# Altium schematic sheet H-9220 Backplane Board - DUT Bd3-4 (REV07).SchDoc
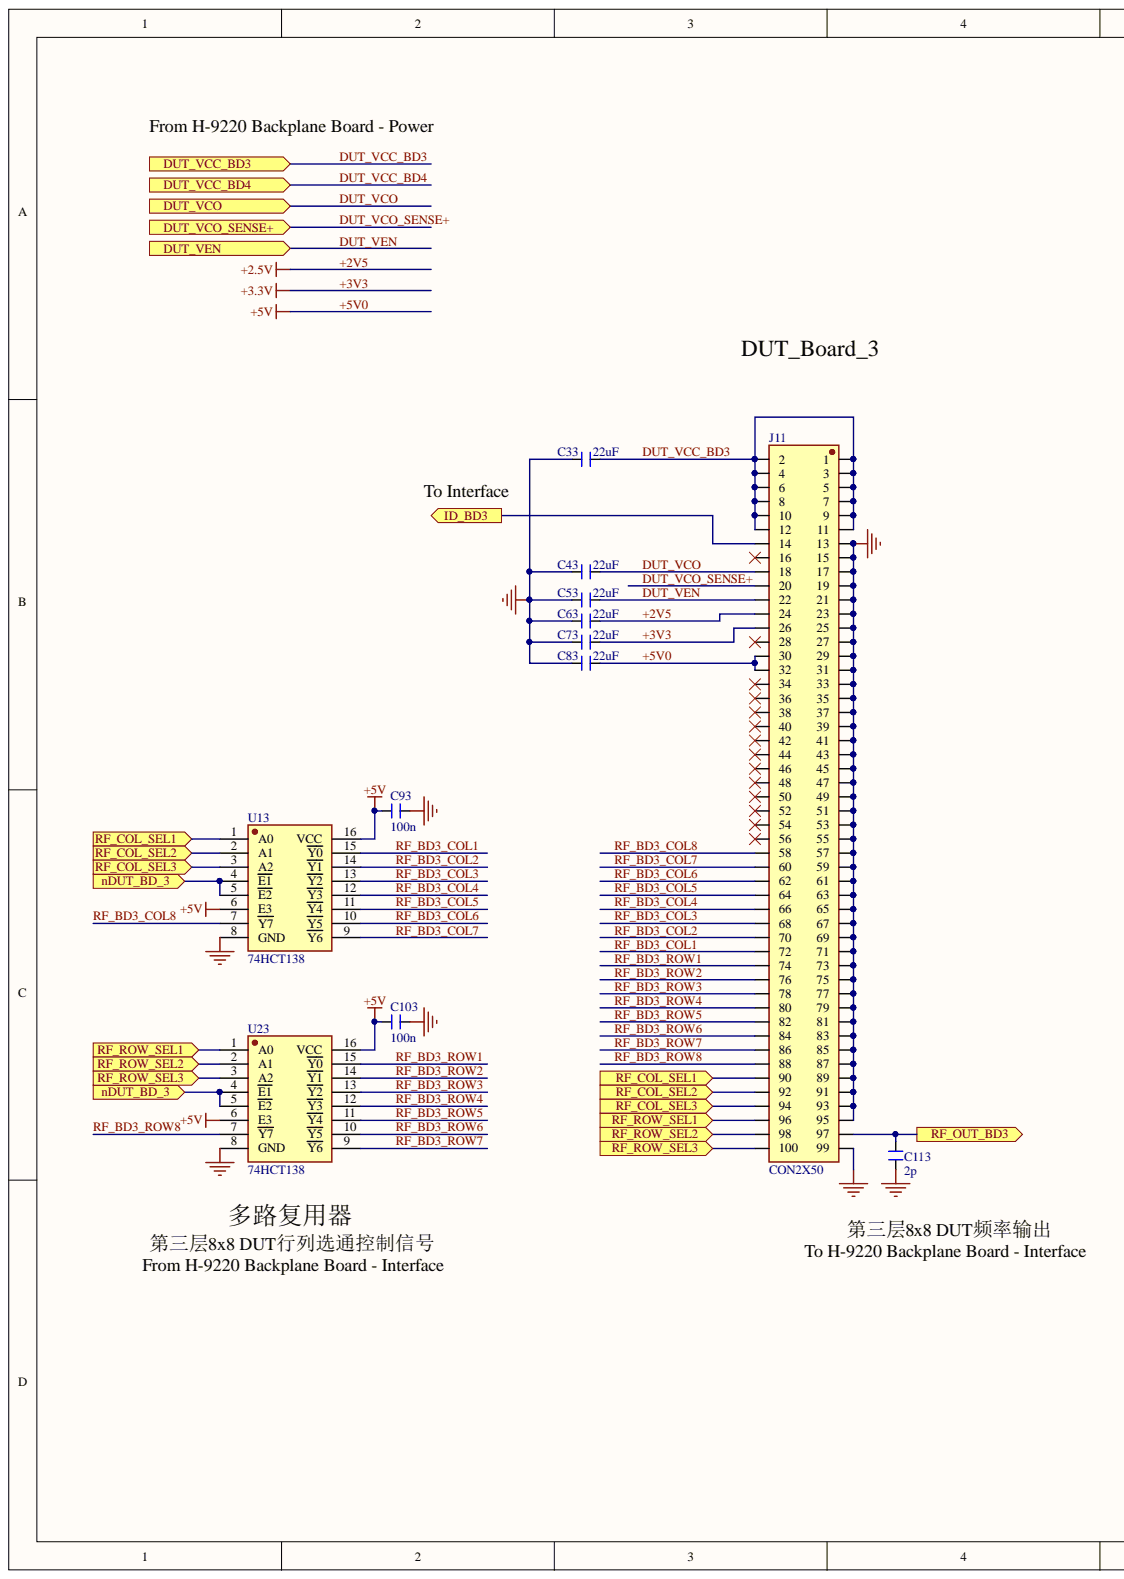
[diagram p0: H-9220 Backplane Board - DUT Bd3-4 (REV07).SchDoc, left]
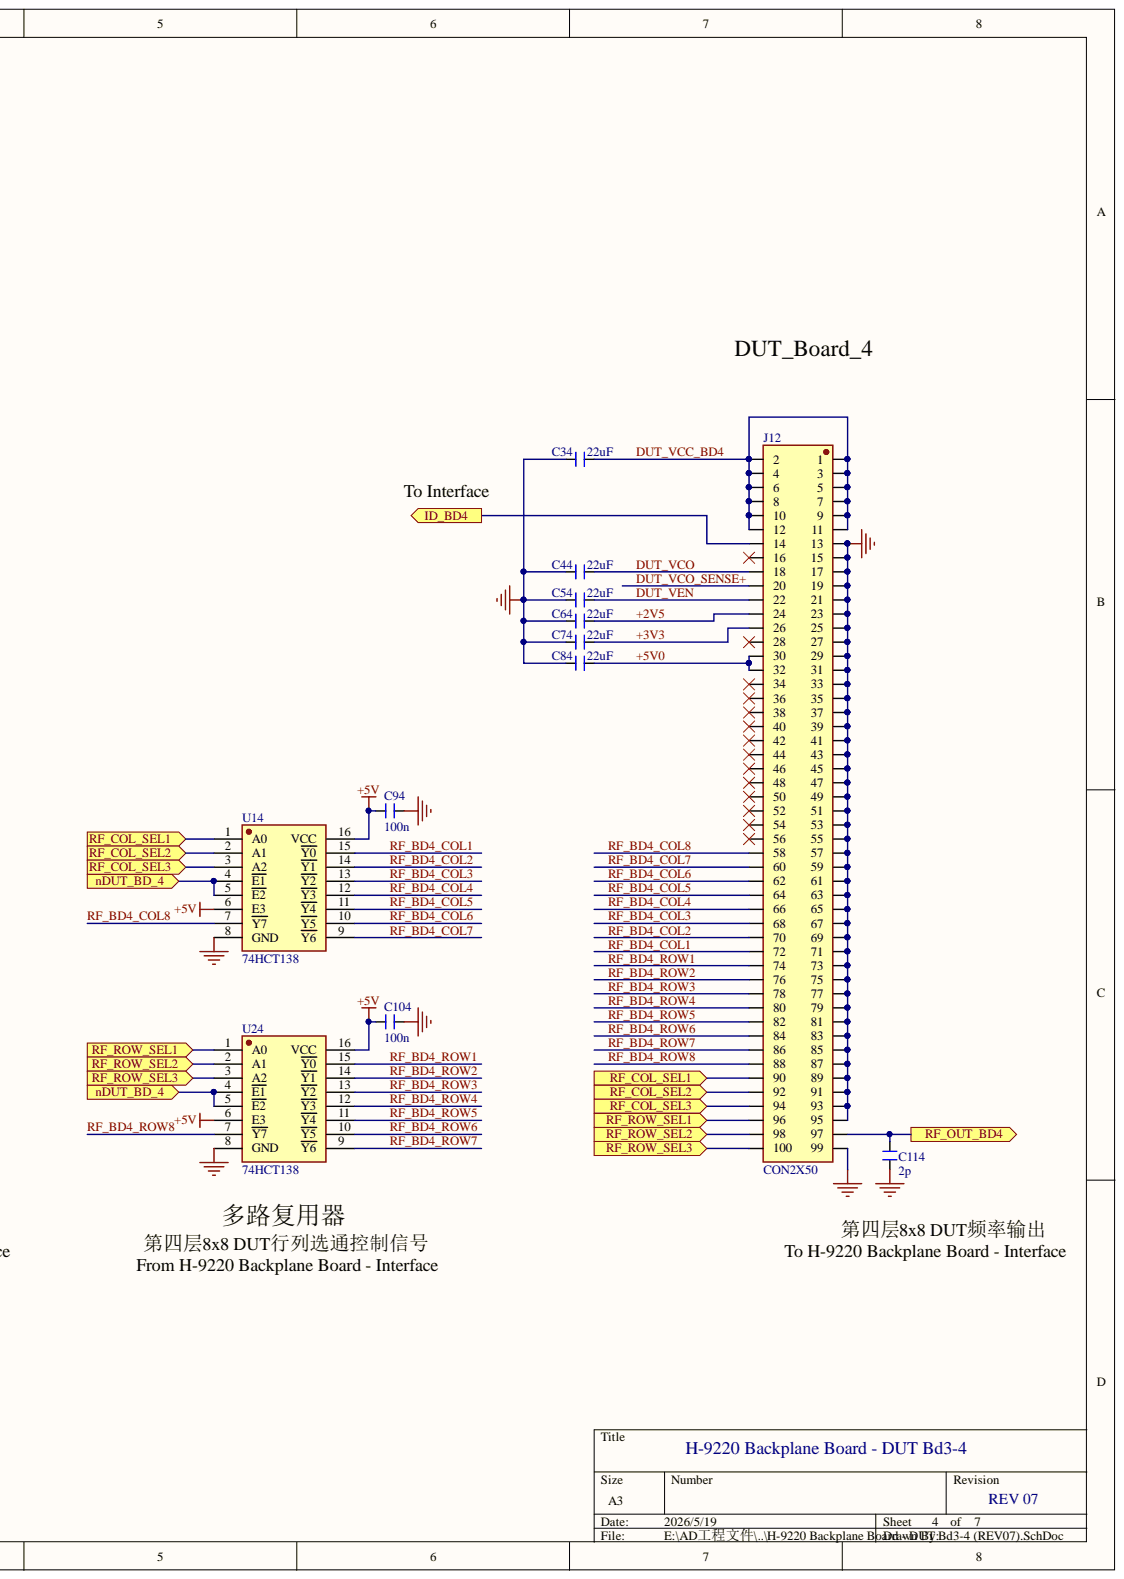
[diagram p1: H-9220 Backplane Board - DUT Bd3-4 (REV07).SchDoc, right]

## Parts
C33  22uF  [C-1206]
C34  22uF  [C-1206]
C43  22uF  [C-1206]
C44  22uF  [C-1206]
C53  22uF  [C-1206]
C54  22uF  [C-1206]
C63  22uF  [C-1206]
C64  22uF  [C-1206]
C73  22uF  [C-1206]
C74  22uF  [C-1206]
C83  22uF  [C-1206]
C84  22uF  [C-1206]
C93  100n  [C-0603]
C94  100n  [C-0603]
C103  100n  [C-0603]
C104  100n  [C-0603]
C113  2p  [C-0603]
C114  2p  [C-0603]
J11  CON2X50  [SFML-150-01-S-D-LC]
J12  CON2X50  [SFML-150-01-S-D-LC]
U13  74HCT138  [SOIC-16 (BODY=3.9MM)]
U14  74HCT138  [SOIC-16 (BODY=3.9MM)]
U23  74HCT138  [SOIC-16 (BODY=3.9MM)]
U24  74HCT138  [SOIC-16 (BODY=3.9MM)]

## Ports
DUT_VCC_BD3, DUT_VCC_BD4, DUT_VCO, DUT_VCO_SENSE+, DUT_VEN, ID_BD3, ID_BD4, nDUT_BD_3, nDUT_BD_4, RF_COL_SEL1, RF_COL_SEL2, RF_COL_SEL3, RF_OUT_BD3, RF_OUT_BD4, RF_ROW_SEL1, RF_ROW_SEL2, RF_ROW_SEL3

## Nets
GND: C33.2 C34.2 C43.2 C44.2 C53.2 C54.2 C63.2 C64.2 C73.2 C74.2 C83.2 C84.2 C93.2 C94.2 C103.2 C104.2 C113.2 C114.2 J11.13 J11.15 J11.17 J11.19 J11.21 J11.23 J11.25 J11.27 J11.29 J11.31 J11.33 J11.35 J11.37 J11.39 J11.41 J11.43 J11.45 J11.47 J11.49 J11.51 J11.53 J11.55 J11.57 J11.59 J11.61 J11.63 J11.65 J11.67 J11.69 J11.71 J11.73 J11.75 J11.77 J11.79 J11.81 J11.83 J11.85 J11.87 J11.89 J11.91 J11.93 J11.95 J11.99 J12.13 J12.15 J12.17 J12.19 J12.21 J12.23 J12.25 J12.27 J12.29 J12.31 J12.33 J12.35 J12.37 J12.39 J12.41 J12.43 J12.45 J12.47 J12.49 J12.51 J12.53 J12.55 J12.57 J12.59 J12.61 J12.63 J12.65 J12.67 J12.69 J12.71 J12.73 J12.75 J12.77 J12.79 J12.81 J12.83 J12.85 J12.87 J12.89 J12.91 J12.93 J12.95 J12.99 U13.8(GND) U14.8(GND) U23.8(GND) U24.8(GND)
+2V5: C63.1 C64.1 J11.24 J12.24
+3V3: C73.1 C74.1 J11.26 J12.26
+5V0: C83.1 C84.1 C93.1 C94.1 C103.1 C104.1 J11.30 J11.32 J12.30 J12.32 U13.6(E3) U13.16(VCC) U14.6(E3) U14.16(VCC) U23.6(E3) U23.16(VCC) U24.6(E3) U24.16(VCC)
DUT_VCC_BD3: C33.1 J11.1 J11.2 J11.3 J11.4 J11.5 J11.6 J11.7 J11.8 J11.9 J11.10 J11.11 J11.12 ; port DUT_VCC_BD3
DUT_VCC_BD4: C34.1 J12.1 J12.2 J12.3 J12.4 J12.5 J12.6 J12.7 J12.8 J12.9 J12.10 J12.11 J12.12 ; port DUT_VCC_BD4
DUT_VCO: C43.1 C44.1 J11.18 J12.18 ; port DUT_VCO
DUT_VCO_SENSE+: J11.20 J12.20 ; port DUT_VCO_SENSE+
DUT_VEN: C53.1 C54.1 J11.22 J12.22 ; port DUT_VEN
ID_BD3: J11.14 ; port ID_BD3
ID_BD4: J12.14 ; port ID_BD4
nDUT_BD_3: U13.4(E1) U13.5(E2) U23.4(E1) U23.5(E2) ; port nDUT_BD_3
nDUT_BD_4: U14.4(E1) U14.5(E2) U24.4(E1) U24.5(E2) ; port nDUT_BD_4
RF_BD3_COL1: J11.72 U13.15(Y0)
RF_BD3_COL2: J11.70 U13.14(Y1)
RF_BD3_COL3: J11.68 U13.13(Y2)
RF_BD3_COL4: J11.66 U13.12(Y3)
RF_BD3_COL5: J11.64 U13.11(Y4)
RF_BD3_COL6: J11.62 U13.10(Y5)
RF_BD3_COL7: J11.60 U13.9(Y6)
RF_BD3_COL8: J11.58 U13.7(Y7)
RF_BD3_ROW1: J11.74 U23.15(Y0)
RF_BD3_ROW2: J11.76 U23.14(Y1)
RF_BD3_ROW3: J11.78 U23.13(Y2)
RF_BD3_ROW4: J11.80 U23.12(Y3)
RF_BD3_ROW5: J11.82 U23.11(Y4)
RF_BD3_ROW6: J11.84 U23.10(Y5)
RF_BD3_ROW7: J11.86 U23.9(Y6)
RF_BD3_ROW8: J11.88 U23.7(Y7)
RF_BD4_COL1: J12.72 U14.15(Y0)
RF_BD4_COL2: J12.70 U14.14(Y1)
RF_BD4_COL3: J12.68 U14.13(Y2)
RF_BD4_COL4: J12.66 U14.12(Y3)
RF_BD4_COL5: J12.64 U14.11(Y4)
RF_BD4_COL6: J12.62 U14.10(Y5)
RF_BD4_COL7: J12.60 U14.9(Y6)
RF_BD4_COL8: J12.58 U14.7(Y7)
RF_BD4_ROW1: J12.74 U24.15(Y0)
RF_BD4_ROW2: J12.76 U24.14(Y1)
RF_BD4_ROW3: J12.78 U24.13(Y2)
RF_BD4_ROW4: J12.80 U24.12(Y3)
RF_BD4_ROW5: J12.82 U24.11(Y4)
RF_BD4_ROW6: J12.84 U24.10(Y5)
RF_BD4_ROW7: J12.86 U24.9(Y6)
RF_BD4_ROW8: J12.88 U24.7(Y7)
RF_COL_SEL1: J11.90 J12.90 U13.1(A0) U14.1(A0) ; port RF_COL_SEL1
RF_COL_SEL2: J11.92 J12.92 U13.2(A1) U14.2(A1) ; port RF_COL_SEL2
RF_COL_SEL3: J11.94 J12.94 U13.3(A2) U14.3(A2) ; port RF_COL_SEL3
RF_OUT_BD3: C113.1 J11.97 ; port RF_OUT_BD3
RF_OUT_BD4: C114.1 J12.97 ; port RF_OUT_BD4
RF_ROW_SEL1: J11.96 J12.96 U23.1(A0) U24.1(A0) ; port RF_ROW_SEL1
RF_ROW_SEL2: J11.98 J12.98 U23.2(A1) U24.2(A1) ; port RF_ROW_SEL2
RF_ROW_SEL3: J11.100 J12.100 U23.3(A2) U24.3(A2) ; port RF_ROW_SEL3

Not connected: J11 16 28 34 36 38 40 42 44 46 48 50 52 54 56; J12 16 28 34 36 38 40 42 44 46 48 50 52 54 56

## Library data of the parts
C33 C34 C43 C44 C53 C54 C63 C64 C73 C74 C83 C84 C93 C94 C103 C104 C113 C114 = CAP: Component Kind: Standard; Library Name: HW SCH Lib.SCHLIB
J11 J12 = CON-2x50P: Component Kind: Standard; Library Name: HW SCH Lib.SCHLIB
U13 U14 U23 U24 = 74HCT138: Component Kind: Standard; Library Name: HW SCH Lib.SCHLIB
